# Altium schematic sheet D.ELI_FETs_L.SchDoc
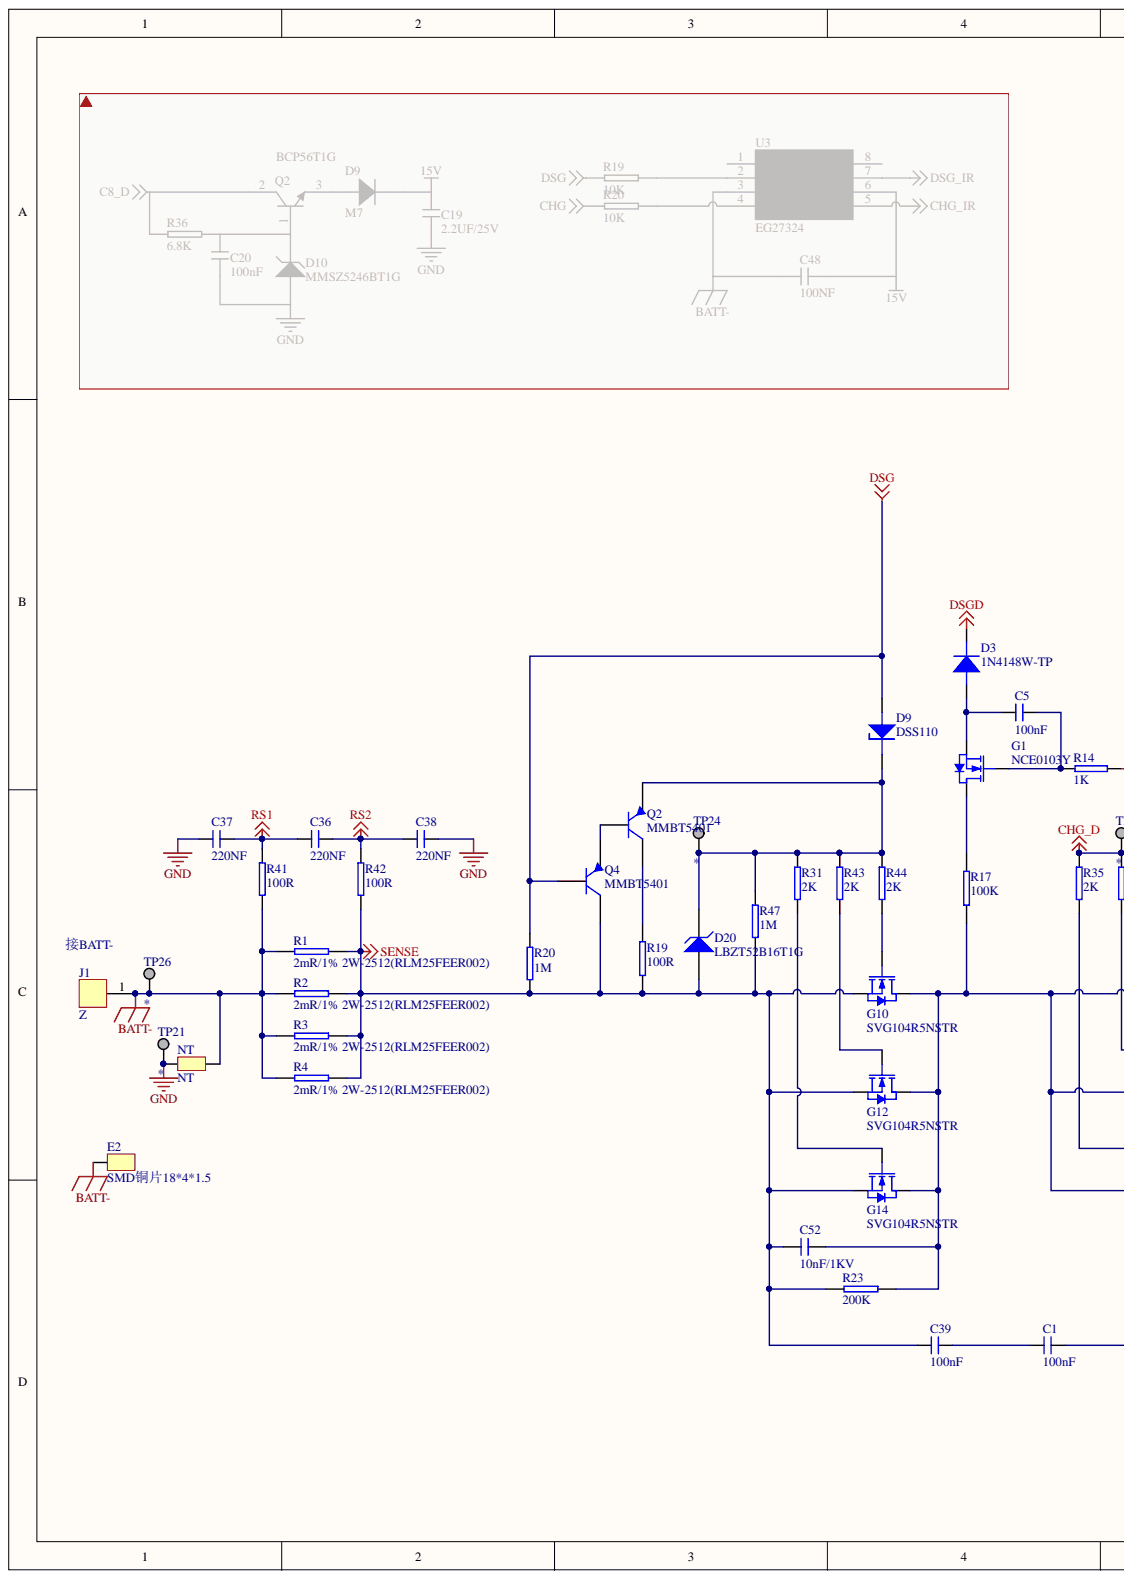
[diagram p0: D.ELI_FETs_L.SchDoc, left]
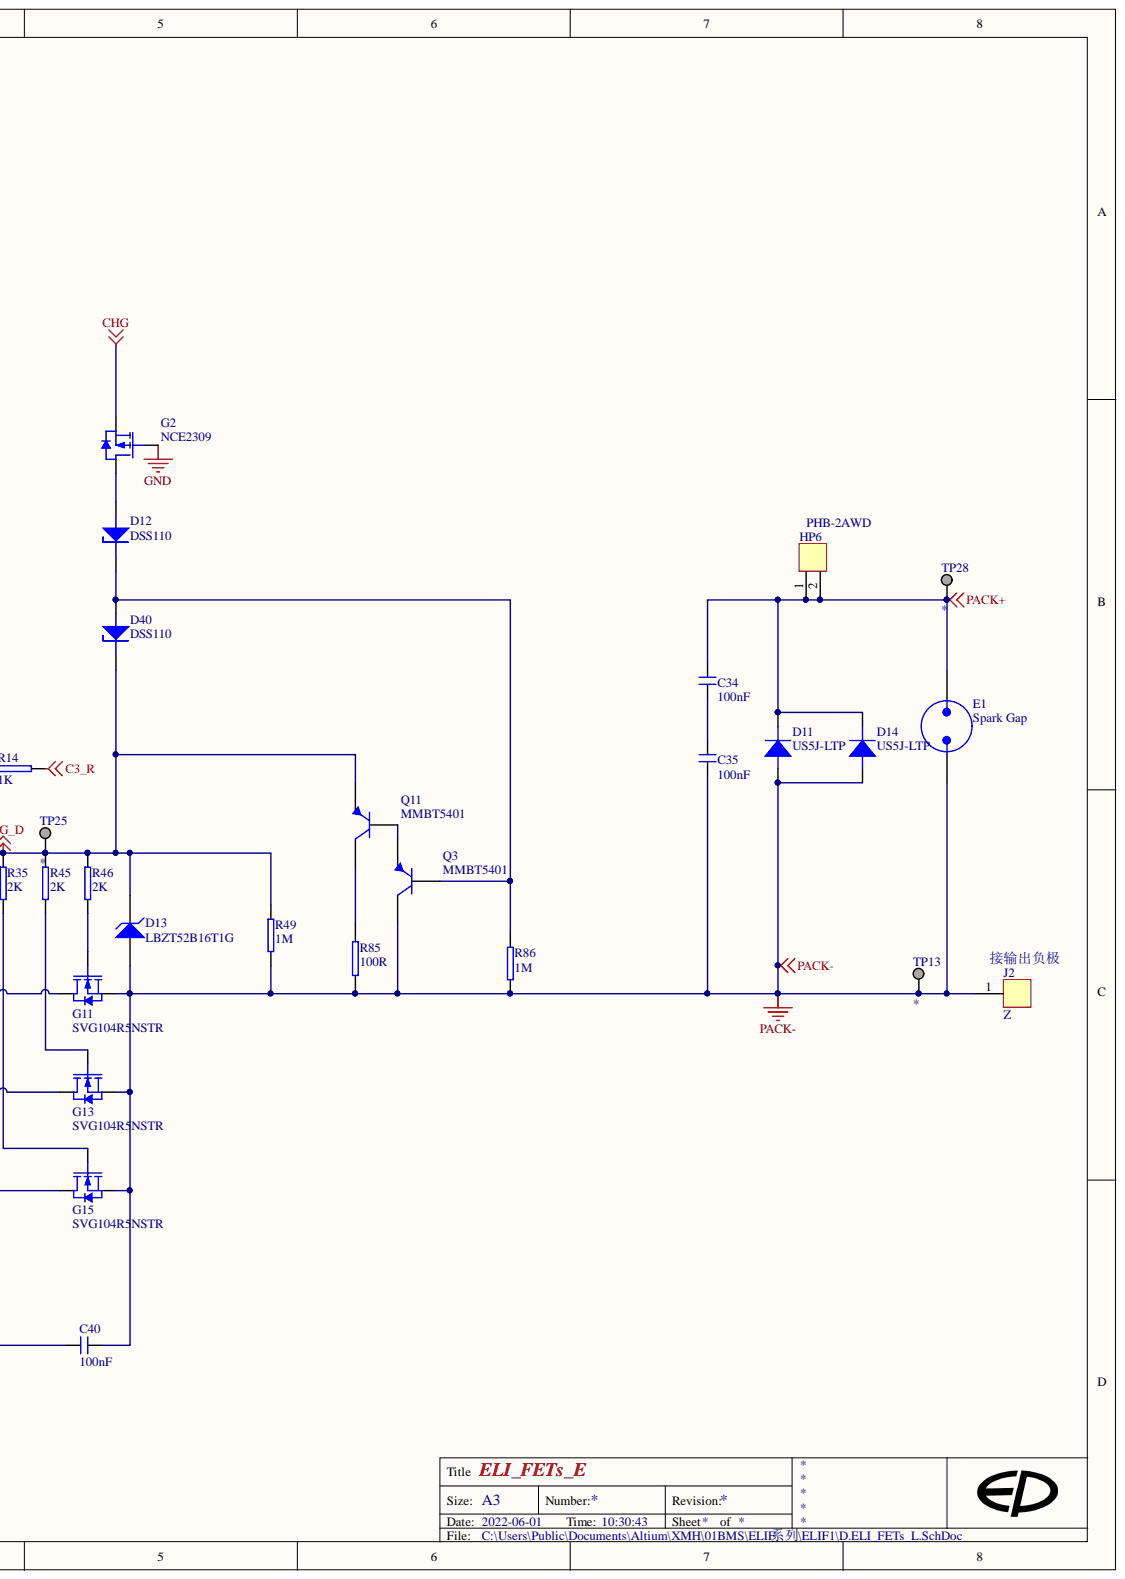
[diagram p1: D.ELI_FETs_L.SchDoc, right]

## Parts
C1  100nF  [0603-C]
C5  100nF  [0603-C]
C34  100nF  [0603-C]
C35  100nF  [0603-C]
C36  220NF  [0603-C]
C37  220NF  [0603-C]
C38  220NF  [0603-C]
C39  100nF  [0603-C]
C40  100nF  [0603-C]
C52  10nF/1KV  [1206]
D3  1N4148W-TP  [SOD-123]
D9  DSS110  [SOD-123]
D11  US5J-LTP  [SMC_C]
D12  DSS110  [SOD-123]
D13  LBZT52B16T1G  [SOD-123]
D14  US5J-LTP  [SMC_C]
D20  LBZT52B16T1G  [SOD-123]
D40  DSS110  [SOD-123]
E1  Spark Gap  [SPARK_GAP2]
E2  SMD铜片18*4*1.5  [SMD-18*4]
G1  NCE0103Y  [SOT-23N]
G2  NCE2309  [SOT-23N]
G10  SVG104R5NSTR  [DDPAK]
G11  SVG104R5NSTR  [DDPAK]
G12  SVG104R5NSTR  [DDPAK]
G13  SVG104R5NSTR  [DDPAK]
G14  SVG104R5NSTR  [DDPAK]
G15  SVG104R5NSTR  [DDPAK]
HP6  PHB-2AWD  [HY2P-H]
J1  Z  [P11.1_9]
J2  Z  [P11.1_9]
NT  NT  [30mil]
Q2  MMBT5401  [SOT-23M]
Q3  MMBT5401  [SOT-23M]
Q4  MMBT5401  [SOT-23M]
Q11  MMBT5401  [SOT-23M]
R1  2mR/1% 2W-2512(RLM25FEER002)  [2512-M]
R2  2mR/1% 2W-2512(RLM25FEER002)  [2512-M]
R3  2mR/1% 2W-2512(RLM25FEER002)  [2512-M]
R4  2mR/1% 2W-2512(RLM25FEER002)  [2512-M]
R14  1K  [0603-R]
R17  100K  [0805-R]
R19  100R  [0805-R]
R20  1M  [0603-R]
R23  200K  [0805-R]
R31  2K  [0805S]
R35  2K  [0805S]
R41  100R  [0603-R]
R42  100R  [0603-R]
R43  2K  [0805S]
R44  2K  [0805S]
R45  2K  [0805S]
R46  2K  [0805S]
R47  1M  [0603-R]
R49  1M  [0603-R]
R85  100R  [0805-R]
R86  1M  [0603-R]
TP13  (no value)  [TESTPOINT60]
TP21  (no value)  [TESTPOINT60]
TP24  (no value)  [TESTPOINT40]
TP25  (no value)  [TESTPOINT40]
TP26  (no value)  [TESTPOINT60]
TP28  (no value)  [TESTPOINT60]

## Nets
BATT-: E2.1 J1.1 NT.2 R1.1 R2.1 R3.1 R4.1 R41.1 TP26.1
C3_R: R14.1
CHG: G2.3
CHG_D: D13.1 D40.1 Q11.2 R35.2 R45.2 R46.2 R49.2 TP25.1
DSG: D9.2 Q4.1 R20.2
DSGD: D3.1
GND: C37.2 C38.1 G2.1 NT.1 TP21.1
PACK+: C34.1 D11.1 D14.1 E1.2 HP6.1 HP6.2 TP28.1
PACK-: C35.2 C40.1 D11.2 D13.2 D14.2 E1.1 G11.3 G13.3 G15.3 J2.1 Q3.3 R49.1 R85.1 R86.1 TP13.1
RS1: C36.2 C37.1 R41.2
RS2: C36.1 C38.2 R42.2
SENSE: C39.2 C52.1 D20.2 G10.3 G12.3 G14.3 Q4.3 R1.2 R2.2 R3.2 R4.2 R19.1 R20.1 R23.1 R42.1 R47.1
NetC1_1: C1.1 C40.2
NetC1_2: C1.2 C39.1
NetC5_1: C5.1 D3.2 G1.3
NetC5_2: C5.2 G1.1 R14.2
NetC34_2: C34.2 C35.1
NetC52_2: C52.2 G10.2 G11.2 G12.2 G13.2 G14.2 G15.2 R17.1 R23.2
NetD9_1: D9.1 D20.1 Q2.2 R31.2 R43.2 R44.2 R47.2 TP24.1
NetD12_1: D12.1 D40.2 Q3.1 R86.2
NetD12_2: D12.2 G2.2
NetG1_2: G1.2 R17.2
NetG10_1: G10.1 R44.1
NetG11_1: G11.1 R46.1
NetG12_1: G12.1 R43.1
NetG13_1: G13.1 R45.1
NetG14_1: G14.1 R31.1
NetG15_1: G15.1 R35.1
NetQ2_1: Q2.1 Q4.2
NetQ2_3: Q2.3 R19.2
NetQ3_2: Q3.2 Q11.1
NetQ11_3: Q11.3 R85.2

## Library data of the parts
C1 C5 C34-C40 = Cap: Component Kind: Standard; Library Name: xmh_c.SchLib
  C1 C5 C34 C35 C39 C40: Value: 100nF
  C36-C38: Value: 220NF
C52 = Cap: 贴片电容; Component Kind: Standard; Value: 10nF/1KV; Library Name: xmh_c.IntLib
D3 = DIODE: 贴片二极管; Parameter 2: SS110-LTP; Parameter 3: SL110PL; Parameter 4: B5819WS; Parameter 5: ES2G-E3/52T; Parameter 6: 1N4148WT-7; Parameter 7: 1N4148WS; Parameter 8: BAV21WS; Component Kind: Standard; Parameter 1: 1N4148W-TP; Value: 1N4148W-TP; Library Name: xmh_c.IntLib
D9 D11 D12 D14 D40 = DIODE: 二极管; Component Kind: Standard
  D9 D12 D40: Value: DSS110; Library Name: xmh_c.SchLib
  D11 D14: Value: US5J-LTP; Library Name: xmh_c.IntLib
D13 D20 = DIODE_W: Component Kind: Standard; Value: LBZT52B16T1G; Library Name: xmh_c.IntLib
E2 = E_SMD: Component Kind: Standard; Library Name: xmh_c.IntLib
G1 G10 G12 G14 = MOSFET-N: Component Kind: Standard; Library Name: xmh_c.SchLib
  G1: Value: NCE0103Y
  G10 G12 G14: Value: SVG104R5NSTR
G2 = MOSFET-P: Component Kind: Standard; Value: NCE2309; Library Name: xmh_c.SchLib
G11 G13 G15 = MOS_N_R: Component Kind: Standard; Value: SVG104R5NSTR; Library Name: xmh_c.SchLib
HP6 = H2P: Component Kind: Standard; Library Name: xmh_c.SchLib
Q2-Q4 Q11 = PNP: 贴片三极管; Component Kind: Standard; Value: MMBT5401; Library Name: xmh_c.IntLib
R1-R4 R17 R19 R23 R85 = RES T: 贴片电阻; Component Kind: Standard; Library Name: xmh_c.IntLib
  R1-R4: Value: 2mR/1% 2W-2512(RLM25FEER002)
  R17: Value: 100K
  R19 R85: Value: 100R
  R23: Value: 200K
R14 R20 R31 R35 R41-R47 R49 R86 = RES T: 电阻; Component Kind: Standard; Library Name: xmh_c.SchLib
  R14: Value: 1K
  R20 R47 R49 R86: Value: 1M
  R31 R35 R43-R46: Value: 2K
  R41 R42: Value: 100R
TP13 TP21 TP24-TP26 TP28 = TESTPOINT: TEST POINT.  No entry in BOM.; Component Kind: Standard; Library Name: xmh_c.IntLib
E1 = Spark Gap: Component Kind: Standard; Library Name: xmh_c.IntLib
J1 J2 = Z: Component Kind: Standard; Library Name: xmh_c.SchLib
NT = NT: Component Kind: Standard; Library Name: xmh_c.IntLib
